# Altium schematic sheet Dental Sensor - CAP.SchDoc("Dental Sensor - CAP")
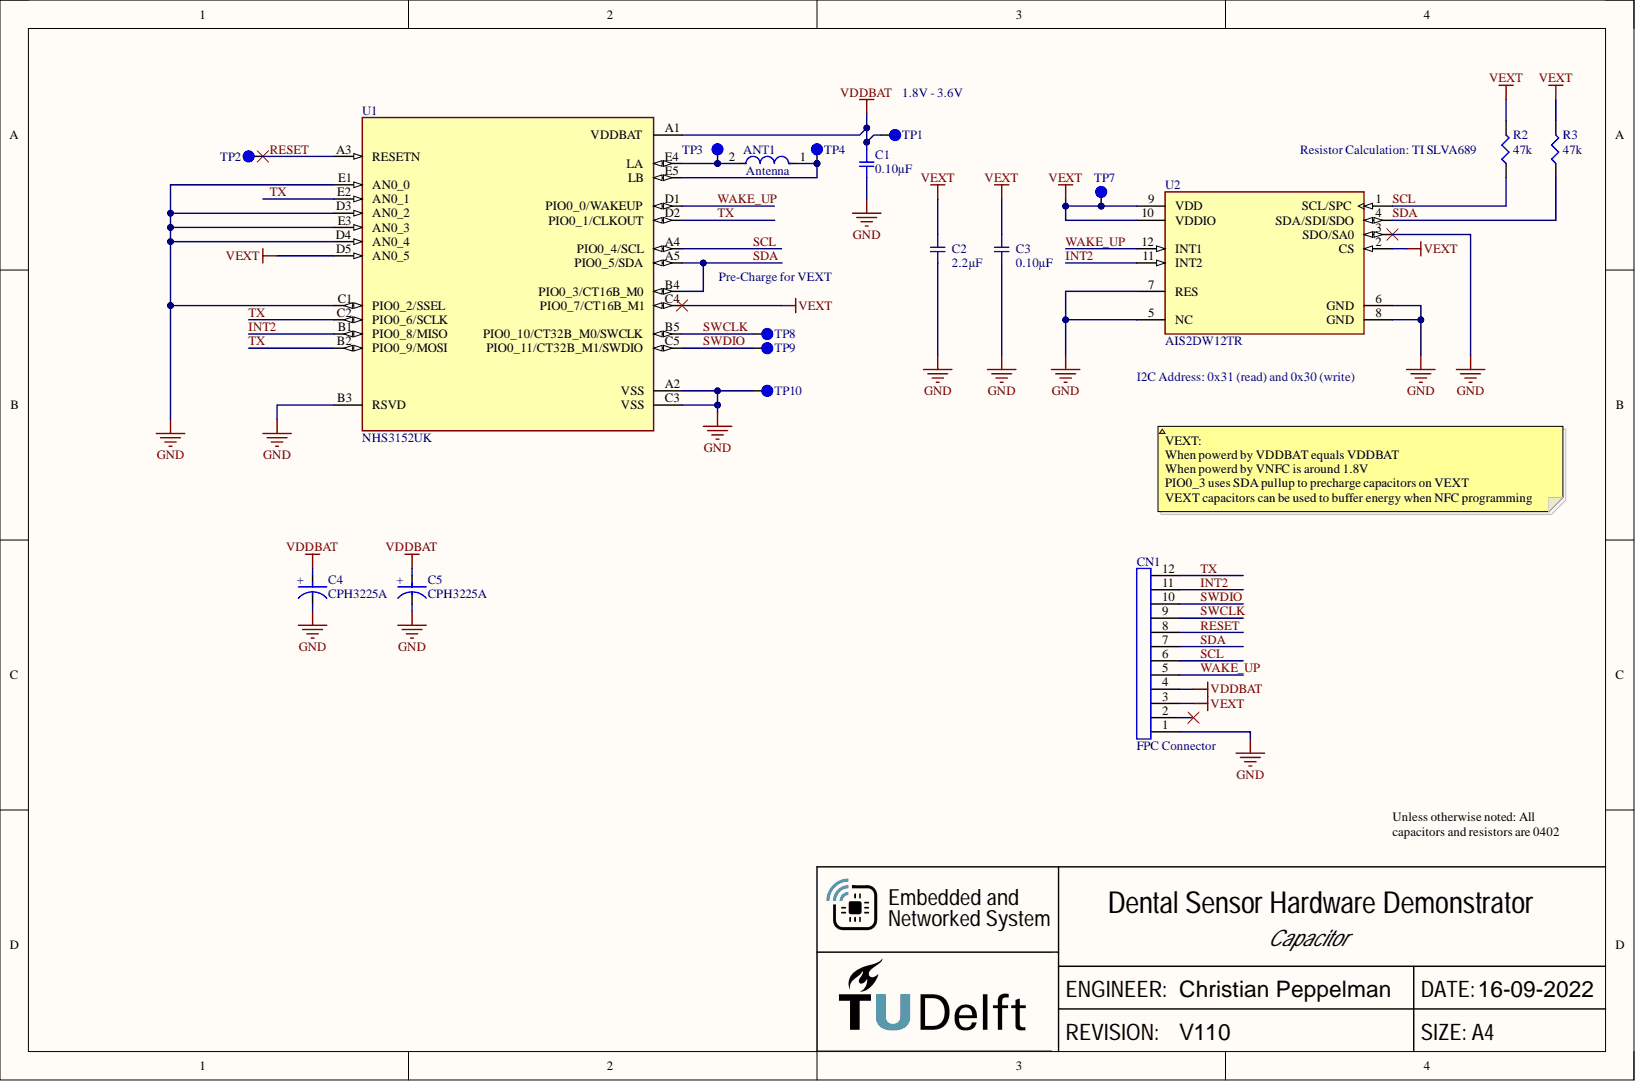
[diagram p0: Dental Sensor - CAP.SchDoc]

## Parts
ANT1  Antenna  [NFC Antenna]
C1  0.10µF  [CAPC1005X03N]
C2  2.2µF  [CAPC1005X03N]
C3  0.10µF  [CAPC1005X03N]
C4  CPH3225A  [CPH3225A]
C5  CPH3225A  [CPH3225A]
CN1  FPC Connector  [52745-1297]
R2  47k  [RESC1005X03N]
R3  47k  [RESC1005X03N]
TP1  Testpoint  [Testpoint 0.35mm]  (value not drawn)
TP2  Testpoint  [Testpoint 0.35mm]  (value not drawn)
TP3  Testpoint  [Testpoint 0.35mm]  (value not drawn)
TP4  Testpoint  [Testpoint 0.35mm]  (value not drawn)
TP7  Testpoint  [Testpoint 0.35mm]  (value not drawn)
TP8  Testpoint  [Testpoint 0.35mm]  (value not drawn)
TP9  Testpoint  [Testpoint 0.35mm]  (value not drawn)
TP10  Testpoint  [Testpoint 0.35mm]  (value not drawn)
U1  NHS3152UK  [WLCSP25]
U2  AIS2DW12TR  [FP-LGA12-DM00369449-MFG]

## Nets
GND: C1.2 C2.2 C3.2 C4.2 C5.2 CN1.1 TP10.1 U1.A2(VSS) U1.B3(RSVD) U1.C1 U1.C3(VSS) U1.D3(AN0_2) U1.D4(AN0_4) U1.E1(AN0_0) U1.E3(AN0_3) U2.3(SDO/SA0) U2.5(NC) U2.6(GND) U2.7(RES) U2.8(GND)
VDDBAT: C1.1 C4.1 C5.1 CN1.4 TP1.1 U1.A1(VDDBAT)
VEXT: C2.1 C3.1 CN1.3 R2.2 R3.2 TP7.1 U1.C4 U1.D5(AN0_5) U2.2(CS) U2.9(VDD) U2.10(VDDIO)
INT2: CN1.11 U1.B1 U2.11(INT2)
RESET: CN1.8 TP2.1 U1.A3(RESETN)
SCL: CN1.6 R2.1 U1.A4 U2.1(SCL/SPC)
SDA: CN1.7 R3.1 U1.A5 U1.B4 U2.4(SDA/SDI/SDO)
SWCLK: CN1.9 TP8.1 U1.B5
SWDIO: CN1.10 TP9.1 U1.C5
TX: CN1.12 U1.B2 U1.C2 U1.D2 U1.E2(AN0_1)
WAKE_UP: CN1.5 U1.D1 U2.12(INT1)
NetANT1_1: ANT1.1 TP4.1 U1.E5(LB)
NetANT1_2: ANT1.2 TP3.1 U1.E4(LA)

Not connected: CN1 2

## Library data of the parts
ANT1 = NFC Antenna: Track 0.2; Gap 0.1; Height 4.6; Width 14.5;; Component Kind: Net Tie; Library Name: Library.SchLib
C1 C3 = GRM155R60J104KA01D: CAP CER 0.1UF 6.3V X5R 0402; Manufacturer: Murata Electronics North America; @ qty: 0; Minimum Quantity: 1; Tolerance: ±10%; Unit Price (USD): 0.1; Applications: General Purpose; Component Kind: Standard; Datasheets: http://www.murata.com/~/media/webrenewal/support/library/catalog/products/capacitor/mlcc/c02e.pdf; Digi-Key Part Number: 490-6287-1-ND; Factory Stock: 0; Features: -; Height - Seated (Max): -; Image: //media.digikey.com/Renders/Murata%20Renders/ZRB-SERIES-0402.jpg; Lead Spacing: -; Library Name: capacitor_murata_0402.IntLib; Mounting Type: Surface Mount, MLCC; Operating Temperature: -55°C ~ 85°C; Package / Case: 0402 (1005 Metric); Packaging: Cut Tape (CT); Part Number: GRM155R60J104KA01D; Part Status: Active; Quantity Available: 356062; Ratings: -; Series: GRM; Size / Dimension: 0.039" L x 0.020" W (1.00mm x 0.50mm); Temperature Coefficient: X5R; Thickness (Max): 0.022" (0.55mm); Value: 0.10µF; Voltage: 6.3V
C2 = GRT155R60J225KE01D: CAP CER 2.2UF 6.3V X5R 0402; Manufacturer: Murata Electronics North America; @ qty: 0; Minimum Quantity: 1; Tolerance: ±10%; Unit Price (USD): 0.12; Applications: Automotive; Component Kind: Standard; Datasheets: http://search.murata.co.jp/Ceramy/image/img/A01X/G101/ENG/GRT155R60J225KE01-01.pdf; Digi-Key Part Number: 490-12250-1-ND; Factory Stock: 0; Features: -; Height - Seated (Max): -; Image: //media.digikey.com/Renders/Murata%20Renders/ZRB-SERIES-0402.jpg; Lead Spacing: -; Lead Style: -; Library Name: capacitor_murata_0402.IntLib; Mounting Type: Surface Mount, MLCC; Operating Temperature: -55°C ~ 85°C; Package / Case: 0402 (1005 Metric); Packaging: Cut Tape (CT); Part Number: GRT155R60J225KE01D; Part Status: Active; Quantity Available: 39775; Ratings: AEC-Q200; Series: GRT; Size / Dimension: 0.039" L x 0.020" W (1.00mm x 0.50mm); Temperature Coefficient: X5R; Thickness (Max): 0.022" (0.55mm); Value: 2.2µF; Voltage: 6.3V
C4 C5 = CPH3225A: Supercapacitors / Ultracapacitors Reflow EDLC 3.3V, 11mF, 160 Ohm; Component Kind: Standard; Library Name: Library.SchLib
CN1 = 52745-1297: FFC / FPC Board Connector, 0.5 mm, 12 Contacts, Receptacle, Easy-On 52745, Surface Mount, Top; Component Kind: Standard; Library Name: Library.SchLib
R2 R3 = ERJ-2RKF4702X: RES SMD 47K OHM 1% 1/10W 0402; Manufacturer: Panasonic Electronic Components; @ qty: 1500; Factory Stock: 0; Minimum Quantity: 1; Power (Watts): 0.1W, 1/10W; Temperature Coefficient: ±100ppm/°C; Unit Price (USD): 0.00496; Component Kind: Standard; Composition: Thick Film; Datasheets: http://industrial.panasonic.com/www-cgi/jvcr13pz.cgi?E+PZ+3+AOA0002+ERJ2RKF4702X+7+WW; Digi-Key Part Number: P47.0KLCT-ND; Failure Rate: -; Features: Automotive AEC-Q200; Height - Seated (Max): 0.016" (0.40mm); Image: //media.digikey.com/Photos/Panasonic%20Photos/ERJ-PA2-Series.jpg; Library Name: Panasonic_ERJ_0402.IntLib; Number of Terminations: 2; Operating Temperature: -55°C ~ 155°C; Package / Case: 0402 (1005 Metric); Packaging: Cut Tape (CT); Part Number: ERJ-2RKF4702X; Part Status: Active; Quantity Available: 230515; Series: ERJ; Size / Dimension: 0.039" L x 0.020" W (1.00mm x 0.50mm); Supplier Device Package: 0402; Tolerance: ±1%; Value: 47k
U1 = NHS3152UK: ARM-microcontrollers - MCU NTAG NHS3152 NFC; Component Kind: Standard; Library Name: Library.SchLib
U2 = CMP-12175-000014-1: MEMS DIGITAL OUTPUT MOTION SENSO; Component Kind: Standard; Library Name: Altium Content Vault; RoHS Compliant: Yes
TP1-TP4 TP7-TP10 = Testpoint: Component Kind: Standard; Library Name: Library.SchLib
